# Altium schematic sheet PLL.SchDoc(PLL)
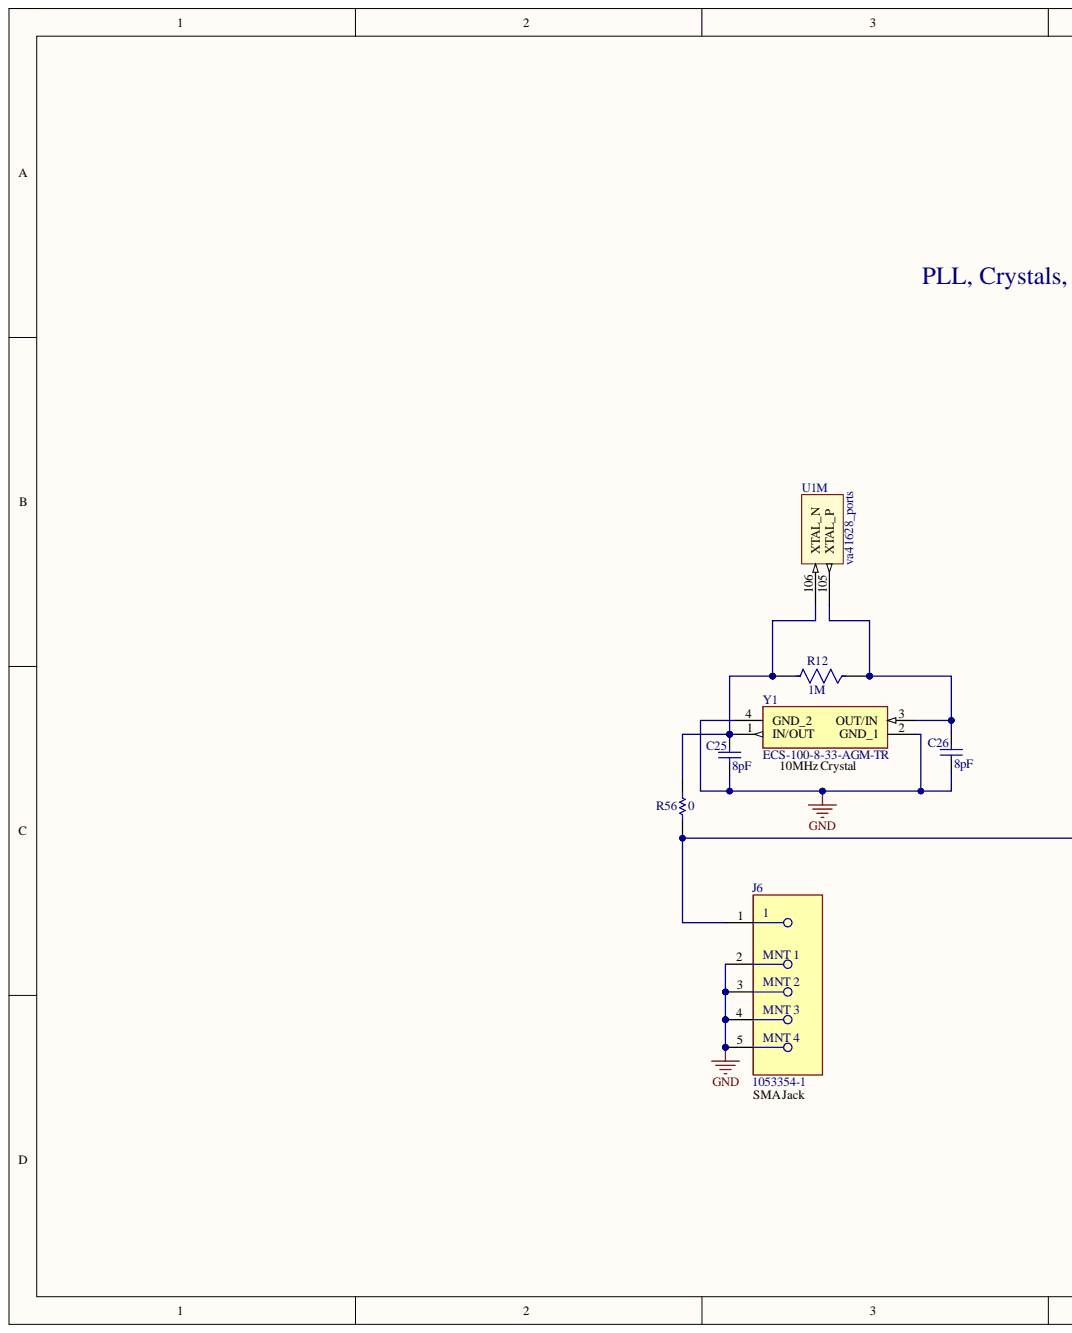
[diagram p0: PLL.SchDoc, left]
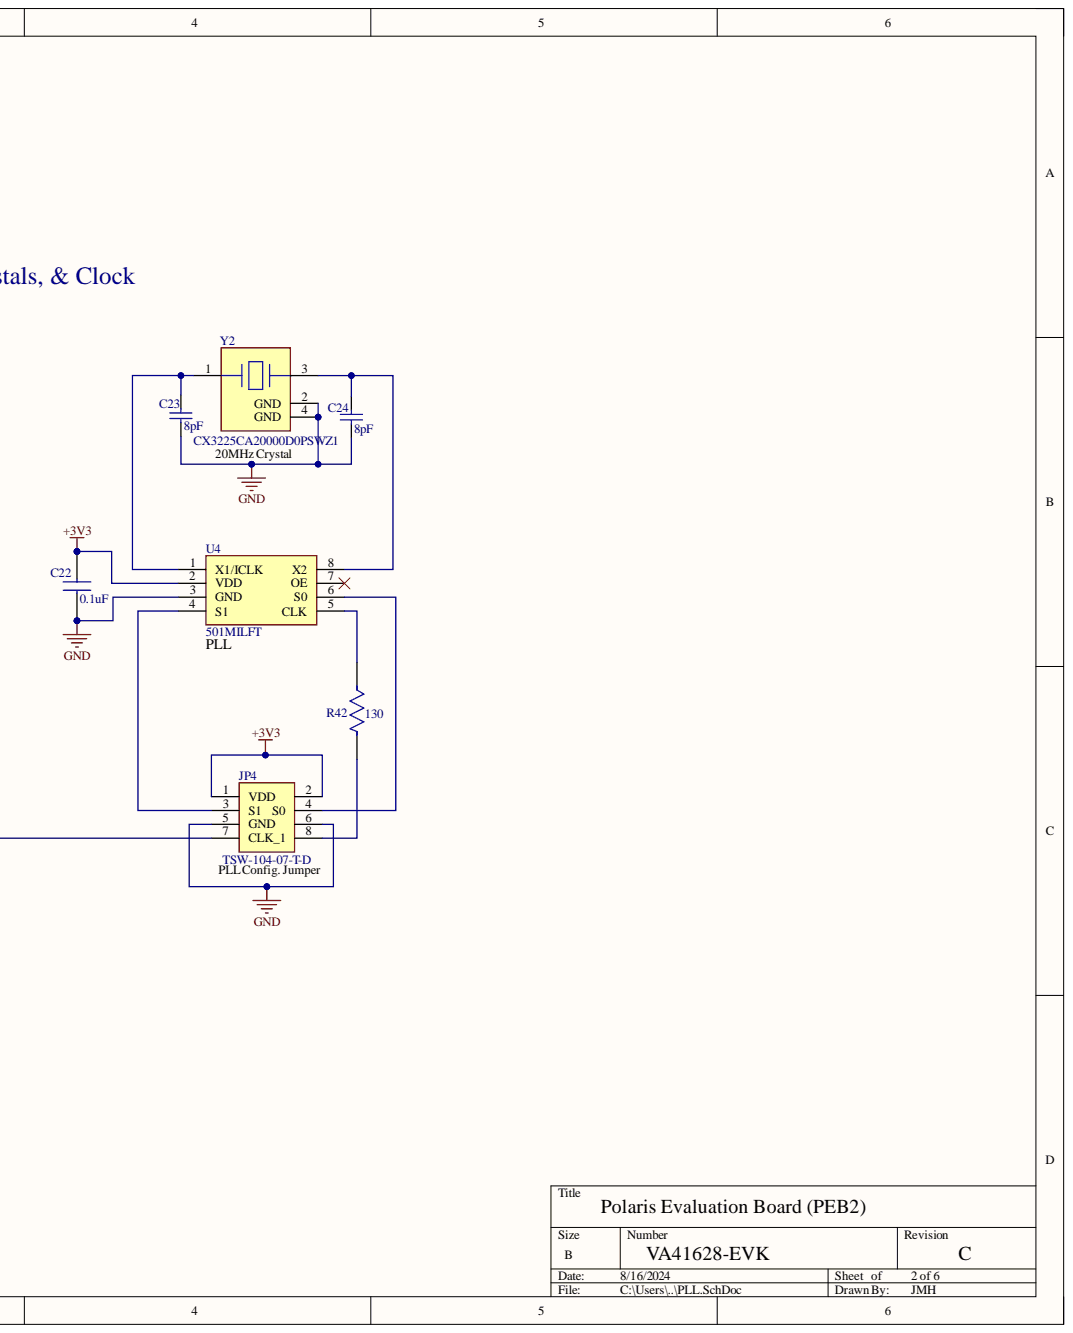
[diagram p1: PLL.SchDoc, right]

## Parts
C22  0.1uF  [C0603C104K3RAC7013]
C23  8pF  [C0603C809C5GACTU]
C24  8pF  [C0603C809C5GACTU]
C25  8pF  [C0603C809C5GACTU]
C26  8pF  [C0603C809C5GACTU]
J6  1053354-1  Case/Package: SMA  [2062-0000-00]
JP4  TSW-104-07-T-D  [TSW-104-07-G-D]
R12  1M  [CRCW08051M00FKEAC]
R42  130  [CRCW0603130RFKEAC]
R56  0  [0OHM]
U1  va41628_ports  [TCQFP-128-0.40-36-C]  (part M)
U4  501MILFT  [501MILFT]
Y1  ECS-100-8-33-AGM-TR  [ECS-100-8-33-AGM-TR]
Y2  CX3225CA20000D0PSWZ1  [CX3225CA20000D0PSWZ1]

## Nets
+3V3: C22.1 JP4.1(VDD) JP4.2 U4.2(VDD)
GND: C22.2 C23.2 C24.2 C25.2 C26.2 J6.2 J6.3 J6.4 J6.5 JP4.5(GND) JP4.6 U4.3(GND) Y1.2(GND_1) Y1.4(GND_2) Y2.2(GND) Y2.4(GND)
NetC23_1: C23.1 U4.1(X1/ICLK) Y2.1
NetC24_1: C24.1 U4.8(X2) Y2.3
NetC25_1: C25.1 R12.2 R56.1 U1.106(XTAL_N) Y1.1(IN/OUT)
NetC26_1: C26.1 R12.1 U1.105(XTAL_P) Y1.3(OUT/IN)
NetJ6_1: J6.1 JP4.7 R56.2
NetJP4_3: JP4.3(S1) U4.4(S1)
NetJP4_4: JP4.4(S0) U4.6(S0)
NetJP4_8: JP4.8(CLK_1) R42.2
NetR42_1: R42.1 U4.5(CLK)

Not connected: U4 7(OE)

## Library data of the parts
C22 = C0603C104K3RAC7013: Capacitor; Manufacturer_Name: KEMET; Component Kind: Standard; Datasheet Link: https://www.kemet.com/specsheet/C0603C104K3RACTM; Height: 0.95mm; Library Name: SamacSys.SchLib; Manufacturer_Part_Number: C0603C104K3RAC7013; Parameter 1: 0.1uF
C23-C26 = CMP-2008-03565-1: Manufacturer: Kemet; Part Number: C0402C809B5GACTU; Component Kind: Standard; Library Name: Altium Content Vault; Parameter 1: 8pF
J6 = CMP-2000-05830-2: CONN SMA JACK STR 50 OHM PCB; Body Material: Stainless Steel; Body Plating: Gold; Dielectric Material: PTFE; Frequency: 18GHz; Gender: Female; Impedance: 50R; Mating Cycles: 500; Max Frequency: 12.4GHz; Number of Ports: 1; PCB Mounting Orientation: Vertical; Polarity: Normal; Center Contact Plating: Gold; Component Kind: Standard; Connector Type: SMA; Contact Material: Copper; Contact Plating: Gold; Depth: 6.3mm; Fastening Type: Threaded; Height: 9.5mm; Housing Color: Gold; Insulation Material: Polytetrafluoroethylene; Length: 9.53mm; Library Name: Altium Content Vault; Mount: Through Hole; Operating Frequency: N/A; Orientation: Straight; Package Quantity: 125; Packaging: Bag; Plating: Gold; Radiation Hardening: No; REACH SVHC: No SVHC; RoHS Compliant: Yes; Series: SMA; Termination: Solder
JP4 = TSW-104-07-T-D: Connector; Manufacturer_Name: SAMTEC; Arrow Part Number: TSW-104-07-T-D; Arrow Price/Stock: https://www.arrow.com/en/products/tsw-104-07-t-d/samtec?region=europe; Component Kind: Standard; Datasheet Link: http://suddendocs.samtec.com/prints/tsw-xxx-xx-xxx-x-xx-xxx-mkt.pdf; Library Name: SamacSys.SchLib; Manufacturer_Part_Number: TSW-104-07-T-D; Mouser Part Number: 200-TSW10407TD; Mouser Price/Stock: https://www.mouser.co.uk/ProductDetail/Samtec/TSW-104-07-T-D?qs=hzq9O0YpnZtBZCcLzm8dag%3D%3D
R12 = CMP-00030-23798584-1: CRCW08051M00FKEAC SMD Chip Resistor, 1 MOhm, ± 1%, 125 mW, 0805 [2012 Metric], Thick Film, General Purpose; Power Rating: 125mW; Temperature Coefficient: 100ppm/°C; Case/Package: Molded; Component Kind: Standard; Composition: Thick Film; Contact Plating: Tin; Lead Free: Lead Free; Length: 2mm; Library Name: Altium Content Vault; Max Operating Temperature: 155°C; Min Operating Temperature: -55°C; Mount: Surface Mount; NexarPartId: 23798584; Resistance: 1M; RoHS: Compliant; Schedule B: 8533210045, 8533210045; Termination: Wraparound; Tolerance: 1%
R42 = CMP-2000-03889-2: RES Thick Film, 130Ω, 1%, 0.1W, 100ppm/°C, 0603; Max Power Dissipation: 100mW; Voltage Rating: 75V; Case Code (Imperial): 0603; Case Code (Metric): 1608; Case/Package: 0603; Component Kind: Standard; Composition: Thick Film; Contact Plating: Tin; Depth: 0.85mm; Features: Automotive AEC-Q200; Height: 0.45mm; Lead Free: Lead Free; Length: 1.55mm; Library Name: Altium Content Vault; Max Operating Temperature: 155°C; Military Standard: Not; Min Operating Temperature: -55°C; Number of Pins: 2; Number of Terminations: 2; Package Quantity: 10; Packaging: Tape and Reel; Power Rating: 100mW; Radiation Hardening: No; Resistance: 130; Temperature Coefficient: 100ppm/°C; Termination: SMD/SMT; Tolerance: 1%; Voltage Rating (DC): 75V; Weight: 7.1E-05oz; Width: 0.85mm; Working Voltage: 75V
R56 = CMP-2000-03816-5: Chip Resistor, 0 Ohm, Jumper, 100 mW, -55 to 155 degC, 0603 (1608 Metric), RoHS, Tape and Reel; Manufacturer: Vishay Semiconductor; Voltage Rating: 75 V; Component Kind: Standard; ComponentLink1Description: Manufacturer URL; ComponentLink1URL: http://www.vishay.com/; ComponentLink2Description: Datasheet; ComponentLink2URL: http://www.vishay.com/docs/20035/dcrcwe3.pdf; DatasheetVersion: 06/2012; Height: 0.45 mm; Length: 1.55 mm; Library Name: Altium Content Vault; Max Operating Temperature: 155 degC; Min Operating Temperature: -55 degC; Mount: Surface Mount; Mounting Technology: Surface Mount; Package Quantity: 5000; PackageDescription: 2-Pin Surface Mount Device, Body 1.575 x 0.85 mm; PackageReference: 0603; Packaging: Tape and Reel; Parameter 1: 0; Pins: 2; REACH SVHC: Unknown; RoHSCompliant: true
U1 = va41628_ports: Polaris Lite 128 pin; Component Kind: Standard; Library Name: va416xx.SchLib
U4 = 501MLFT: Integrated Circuit; Manufacturer_Name: Renesas Electronics; Component Kind: Standard; Datasheet Link: https://www.idt.com/document/dst/501-datasheet; Height: 1.75mm; Manufacturer_Part_Number: 501MLFT; Mouser Part Number: 972-501MLFT
Y1 = ECS-100-8-33-AGM-TR: Crystal or Oscillator; Manufacturer_Name: ECS; Component Kind: Standard; Datasheet Link: https://www.mouser.com/datasheet/2/122/ecx-32-1100709.pdf; Height: 0.8mm; Manufacturer_Part_Number: ECS-100-8-33-AGM-TR; Mouser Part Number: 520-100-8-33-AGMT; Mouser Price/Stock: https://www.mouser.co.uk/ProductDetail/ECS/ECS-100-8-33-AGM-TR?qs=byeeYqUIh0MeX3w9Jop%2F1A%3D%3D
Y2 = CMP-27762-015496-1: Crystal 20MHz ±30ppm (Tol) ±100ppm (Stability) 18pF FUND 50Ω Automotive 4-Pin Ultra Mini-CSMD T/R; Component Kind: Standard; Library Name: Altium Content Vault; RoHS Compliant: Yes
